# Altium schematic sheet WBZ451mikroBUStoPL46EKadapter.SchDoc(WBZ451mikroBUStoPL46EKadapter)
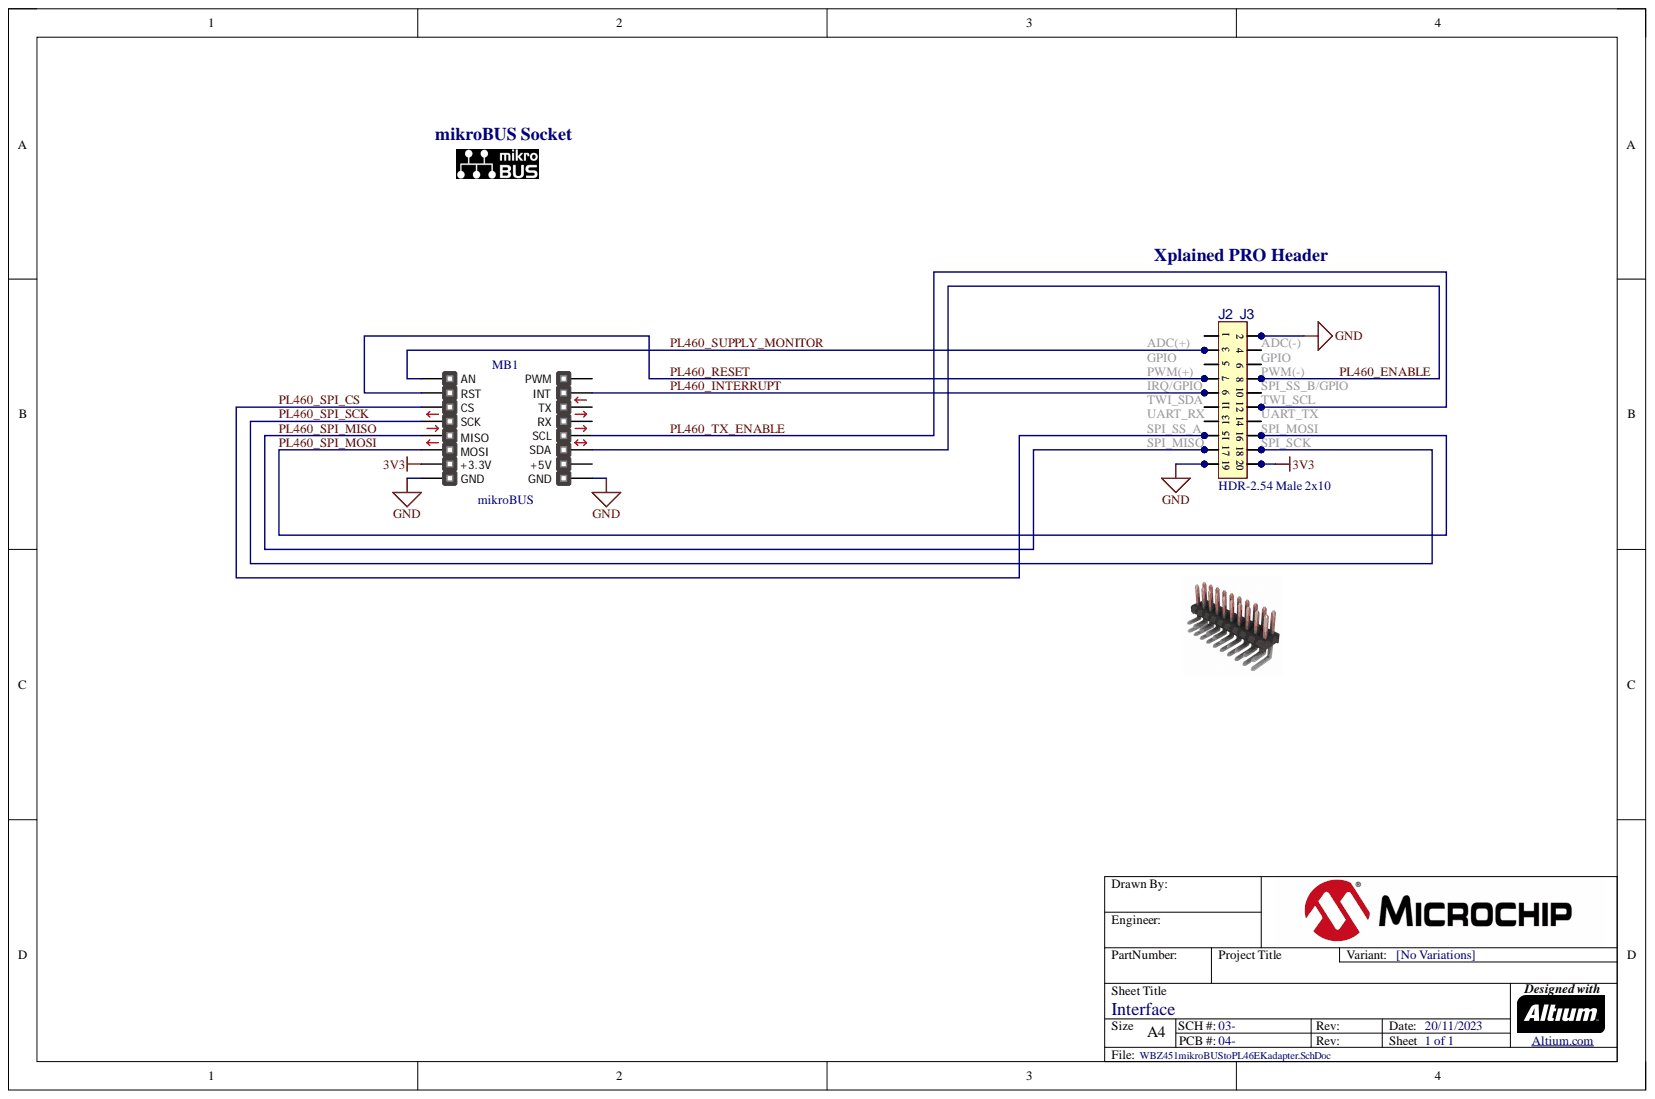
[diagram p0: WBZ451mikroBUStoPL46EKadapter.SchDoc]

## Parts
J2  Header 2x10  [HDR_M_2.54_2x10_RA_180]  (value not drawn)
J3  value HDR-2.54 Male 2x10  [HDR_M_2.54_2x10]  (hidden comment: Header 2x10)
MB1  mikroBUS  [mikroBUS - Mini]  (no BOM)

## Nets
3V3: J2.20 J3.20 MB1.7(+3.3V)
GND: J2.2 J2.19 J3.2 J3.19 MB1.8(GND) MB1.9(GND)
PL460_ENABLE: J2.8 J3.8 MB1.11(SDA)
PL460_INTERRUPT: J2.9 J3.9 MB1.15(INT)
PL460_RESET: J2.7 J3.7 MB1.2(RST)
PL460_SPI_CS: J2.15 J3.15 MB1.3(CS)
PL460_SPI_MISO: J2.17 J3.17 MB1.5(MISO)
PL460_SPI_MOSI: J2.16 J3.16 MB1.6(MOSI)
PL460_SPI_SCK: J2.18 J3.18 MB1.4(SCK)
PL460_SUPPLY_MONITOR: J2.3 J3.3 MB1.1(AN)
PL460_TX_ENABLE: J2.12 J3.12 MB1.12(SCL)
NetJ2_1: J2.1 J3.1
NetJ2_4: J2.4 J3.4
NetJ2_5: J2.5 J3.5
NetJ2_6: J2.6 J3.6
NetJ2_10: J2.10 J3.10
NetJ2_11: J2.11 J3.11
NetJ2_13: J2.13 J3.13
NetJ2_14: J2.14 J3.14

Not connected: MB1 10(+5V) 13(RX) 14(TX) 16(PWM)

## Library data of the parts
J2 = CON1547: Manufacturer 1: Sullins Connector Solutions; Manufacturer Part Number 1: PBC10DBAN; Database Table Name: Connector; Description_: CON HDR-2.54 Male 2x10 Rotated 180Degrees Gold TH RT ANGLE; Dev Tools Status: Production; Owner: Jamus Griego; Populated: YES; Value: HDR-2.54 Male 2x10 RA Rotated 180; Category: Connector; Component Kind: Standard; ComponentLink1Description: SVN Datasheet; ComponentLink1URL: https://chn-vm-apps.microchip.com/mchprepo/parts/Datasheets/77_PAGE108-109_.100_MALE_HDR.pdf; ComponentLink2Description: Local Datasheet; ComponentLink3Description: Open Symbol; ComponentLink4Description: Open Footprint; ComponentLink5Description: Open Footprint 2; ComponentLink6Description: Open Footprint 3; ComponentLink7Description: Open Footprint 4; Library Name: MCHP-CDB.DbLib; MCHP-ID: CON1547; Quantity Override: 1; Revision: 1; Status: MCL Design; Supplier 1: Digi-Key; Supplier Part Number 1: S2111E-10-ND; Symbol: Header 2x10; Type: Header 2.54mm; ComponentLink2URL: C:\ALTIUM_WORK\00001_MCHP-CDB\Datasheets\77_PAGE108-109_.100_MALE_HDR.pdf; ComponentLink3URL: C:\ALTIUM_WORK\00001_MCHP-CDB\Library\LibraryRefs\Header 2x10.SchLib; ComponentLink4URL: C:\ALTIUM_WORK\00001_MCHP-CDB\Library\Footprints\HDR_M_2.54_2x10_RA_180.PcbLib
J3 = CON1171: Manufacturer 1: Samtec; Manufacturer Part Number 1: TSW-110-07-G-D; Database Table Name: Connector; Description_: CON HDR-2.54 Male 2x10 Gold 5.84MH TH VERT; Dev Tools Status: Production; Owner: Mike Ragsdale; Populated: YES; Category: Connector; Component Kind: Standard; ComponentLink1Description: SVN Datasheet; ComponentLink1URL: https://chn-vm-apps.microchip.com/mchprepo/parts/Datasheets/67996.pdf; ComponentLink2Description: Local Datasheet; ComponentLink3Description: Open Symbol; ComponentLink4Description: Open Footprint; ComponentLink5Description: Open Footprint 2; ComponentLink6Description: Open Footprint 3; ComponentLink7Description: Open Footprint 4; Library Name: MCHP-CDB.DbLib; Manufacturer 2: SHENZHEN JUNHAO ENGINEERING; Manufacturer Part Number 2: R-213-2011-0021-400; MCHP-ID: CON1171; Quantity Override: 1; Revision: 2; Status: MCL Design; Supplier 1: Digi-Key; Supplier 2: SHENZHEN JUNHAO ENGINEERING; Supplier Part Number 1: SAM1028-10-ND; Supplier Part Number 2: R-213-2011-0021-400; Symbol: Header 2x10; Type: Header 2.54mm; ComponentLink2URL: C:\ALTIUM_WORK\00001_MCHP-CDB\Datasheets\67996.pdf; ComponentLink3URL: C:\ALTIUM_WORK\00001_MCHP-CDB\Library\LibraryRefs\Header 2x10.SchLib; ComponentLink4URL: C:\ALTIUM_WORK\00001_MCHP-CDB\Library\Footprints\HDR_M_2.54_2x10.PcbLib
MB1 = mikroBUS compatible add-on board: Description: mikroBUS compatible add-on board; Library Name: mikroBUS_Add-on_standard.SchLib
